# Altium schematic sheet MSXIV_DC-DC_Converter.SchDoc
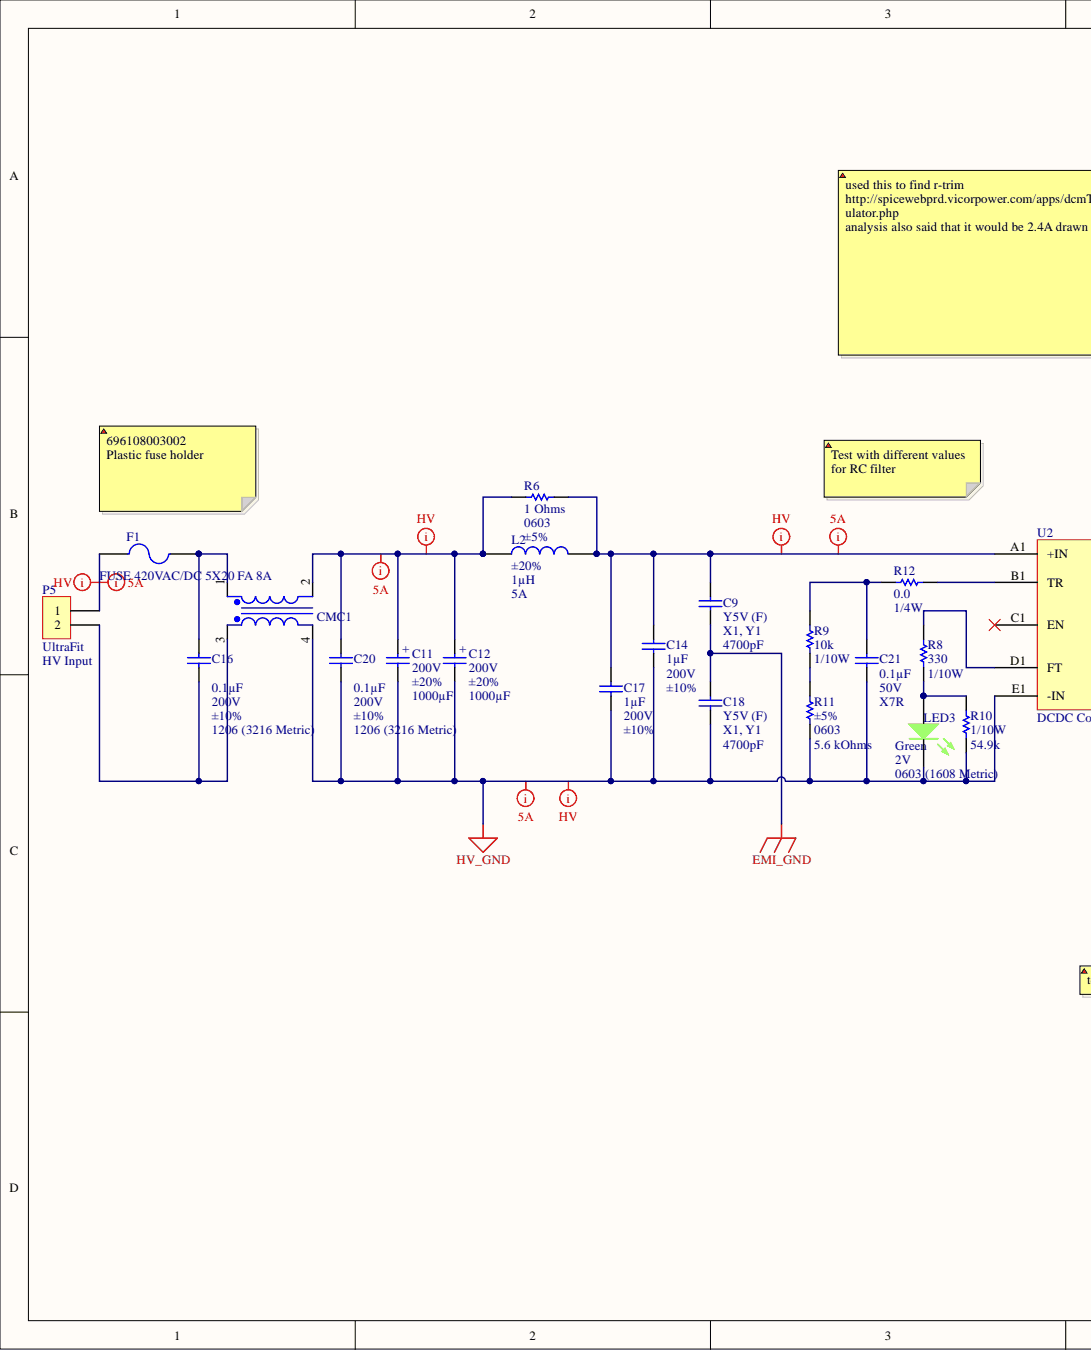
[diagram p0: MSXIV_DC-DC_Converter.SchDoc, left]
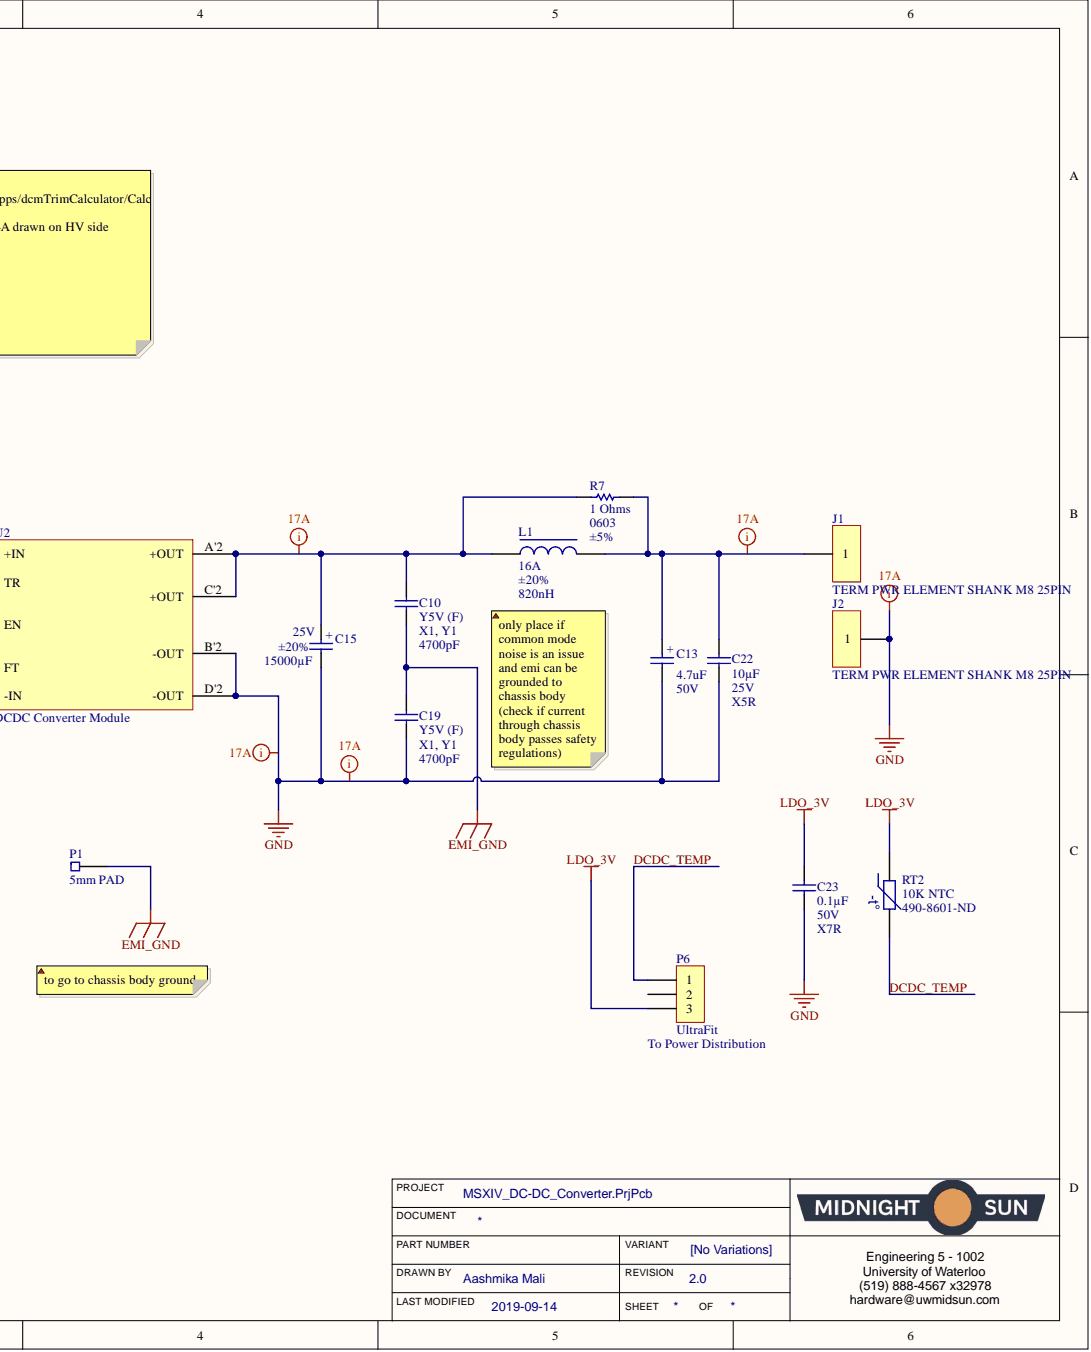
[diagram p1: MSXIV_DC-DC_Converter.SchDoc, right]

## Parts
C9  value 4700pF  Ratings: X1, Y1  Temperature Coefficient: Y5V (F)  [CAP 4700PF 400VAC Y5V RADIAL]  (hidden comment: CAP CER 4700PF 400VAC Y5V RADIAL)
C10  value 4700pF  Ratings: X1, Y1  Temperature Coefficient: Y5V (F)  [CAP 4700PF 400VAC Y5V RADIAL]  (hidden comment: CAP CER 4700PF 400VAC Y5V RADIAL)
C11  Capacitance: 1000µF  Tolerance: ±20%  Voltage - Rated: 200V  [CAP ALUM 1000uF 200V]  (hidden comment: CAP ALUM 1000UF 20% 200V SNAP)
C12  Capacitance: 1000µF  Tolerance: ±20%  Voltage - Rated: 200V  [CAP ALUM 1000uF 200V]  (hidden comment: CAP ALUM 1000UF 20% 200V SNAP)
C13  Capacitance: 4.7uF  Voltage Rating: 50V  [CAP ALUM 6.3MM RADIAL SMD]  (hidden comment: EEE-1HA4R7NP)
C14  Capacitance: 1µF  Voltage - Rated: 200V  [CAP, 2220]  (hidden comment: CAP CER 1UF 200V X7R 2220)
C15  Capacitance: 15000µF  Tolerance: ±20%  Voltage - Rated: 25V  [CAP ALUM 1000uF 200V]  (hidden comment: CAP ALUM 15000UF 20% 25V SNAP)
C16  Capacitance: 0.1µF  Tolerance: ±10%  Voltage - Rated: 200V  [CAP, 1206]  (hidden comment: CAP CER 0.1UF 200V X7R 1206)
C17  Capacitance: 1µF  Tolerance: ±10%  Voltage - Rated: 200V  [CAP, 2220]  (hidden comment: CAP CER 1UF 200V X7R 2220)
C18  value 4700pF  Ratings: X1, Y1  Temperature Coefficient: Y5V (F)  [CAP 4700PF 400VAC Y5V RADIAL]  (hidden comment: CAP CER 4700PF 400VAC Y5V RADIAL)
C19  value 4700pF  Ratings: X1, Y1  Temperature Coefficient: Y5V (F)  [CAP 4700PF 400VAC Y5V RADIAL]  (hidden comment: CAP CER 4700PF 400VAC Y5V RADIAL)
C20  Capacitance: 0.1µF  Tolerance: ±10%  Voltage - Rated: 200V  [CAP, 1206]  (hidden comment: CAP CER 0.1UF 200V X7R 1206)
C21  Capacitance: 0.1µF  Voltage: 50V  [CAP, 0603]  (hidden comment: CAP CER 0.1UF 50V 10% X7R 0603)
C22  Capacitance: 10µF  Temperature Coefficient: X5R  Voltage: 25V  [CAP, 0603]  (hidden comment: CAP CER 10uF 25V 20% X5R 0603)
C23  Capacitance: 0.1µF  Temperature Coefficient: X7R  Voltage: 50V  [CAP, 0603]  (hidden comment: CAP CER 0.1UF 50V 10% X7R 0603)
CMC1  CM Choke  [LCCI 50 Common mode choke]  (value not drawn)
F1  FUSE 420VAC/DC 5X20 FA 8A  [FUSE 420VAC/DC 5X20 FA 8A]
J1  TERM PWR ELEMENT SHANK M8 25PIN  [TERM PWR ELEMENT SHANK M8 25PIN]
J2  TERM PWR ELEMENT SHANK M8 25PIN  [TERM PWR ELEMENT SHANK M8 25PIN]
L1  Current Rating (Amps): 16A  Inductance: 820nH  Tolerance: ±20%  [IND 820NH 16A 6 MOHM SMD]  (hidden comment: IND 820NH 16A 6 MOHM SMD)
L2  Current Rating (Amps): 5A  Inductance: 1µH  Tolerance: ±20%  [IND 1UH 5A 12MOHM SMD]  (hidden comment: IND 1UH 5A 12MOHM SMD)
LED3  Color: Green  Package / Case: 0603 (1608 Metric)  Supplier Device Package: 0603  Voltage - Forward (Vf) (Typ): 2V  [LED_0603]  (hidden comment: LED GREEN CLEAR 2V 0603)
P1  5mm PAD  [5mm PAD]
P5  Series: UltraFit  [CONN, 2POS ULTRA-FIT]  (hidden comment: CONN 2POS ULTRA-FIT 0.138")
P6  Series: UltraFit  [CONN, 3POS ULTRA-FIT]  (hidden comment: CONN 3POS ULTRA-FIT 0.138")
R6  Resistance: 1 Ohms  [RES, 0603]  (hidden comment: RES 1 OHM 5% 1/10W 0603)
R7  Resistance: 1 Ohms  [RES, 0603]  (hidden comment: RES 1 OHM 5% 1/10W 0603)
R8  Power: 1/10W  [RES, 0603]  (hidden comment: RES 330 OHM 1% 1/10W 0603)
R9  Power: 1/10W  Resistance: 10k  [RES, 0603]  (hidden comment: RES 10K OHM 1% 1/10W 0603)
R10  Power (Watts): 1/10W  Resistance (Ohms): 54.9k  [RES, 0603]  (hidden comment: RES 54.9K OHM 1% 1/10W 0603)
R11  Resistance: 5.6 kOhms  Supplier Device Package: 0603  Tolerance: ±5%  [RES, 0603]  (hidden comment: RES 15.6K OHM 0.1% 1/10W 0402)
R12  Resistance: 0.0  [RES, 0603]  (hidden comment: RES 0.0 OHM 1/4W 0603)
RT2  10K NTC  Supplier Part Number 1: 490-8601-ND  [NTC THERMISTOR BEAD]
U2  Type: DCDC Converter Module  [IC, DCDC converter DCM3623TA5N17B4T70]  (hidden comment: DCDC VICOR CONVERTER 15V 240W - DCM3623TA5N17B4T70)

## Nets
EMI_GND: C9.2 C10.2 C18.1 C19.1 P1.1
GND: C13.2 C15.2 C19.2 C22.2 C23.2 J2.1 U2.B'2(-OUT) U2.D'2(-OUT)
HV_GND: C11.2 C12.2 C14.2 C17.2 C18.2 C20.2 C21.2 CMC1.4 LED3.2 R10.2 R11.1 U2.E1(-IN)
LDO_3V: C23.1 P6.3 RT2.1
DCDC_TEMP: P6.1 RT2.2
NetC9_1: C9.1 C14.1 C17.1 L2.2 R6.2 U2.A1(+IN)
NetC10_1: C10.1 C15.1 L1.1 R7.1 U2.A'2(+OUT) U2.C'2(+OUT)
NetC11_1: C11.1 C12.1 C20.1 CMC1.2 L2.1 R6.1
NetC13_1: C13.1 C22.1 J1.1 L1.2 R7.2
NetC16_1: C16.1 CMC1.1 F1.2
NetC16_2: C16.2 CMC1.3 P5.2
NetC21_1: C21.1 R9.1 R12.1
NetF1_1: F1.1 P5.1
NetLED3_1: LED3.1 R8.2 R10.1
NetR8_1: R8.1 U2.D1(FT)
NetR9_2: R9.2 R11.2
NetR12_2: R12.2 U2.B1(TR)

Not connected: P6 2; U2 C1(EN)

## Library data of the parts
C9 C10 C18 C19 = CAP CER 4700PF 400VAC Y5V RADIAL: 4700pF ±20% 400VAC Ceramic Capacitor Y5V (F) Radial, Disc; Manufacturer: KEMET; Manufacturer Part Number: C951U472MVVDBA7317; Applications: Safety; Category: Capacitors; Component Kind: Standard; ComponentLink1Description: https://search.kemet.com/component-edge/download/datasheet/C951U472MVVDBA7317.pdf; ComponentLink1URL: https://search.kemet.com/component-edge/download/datasheet/C951U472MVVDBA7317.pdf; Failure Rate: -; Features: -; Height - Seated (Max): -; Lead Spacing: 0.394" (10.00mm); Lead Style: Formed Leads - Kinked; Mounting Type: Through Hole; Operating Temperature: -40°C ~ 125°C; Package / Case: Radial, Disc; Packaging: Cut Tape (CT); Part Status: Active; Pricing 1: 1=1.12, 10=0.795, 100=0.5234, 500=0.38772 (CAD); RoHS: RoHS Compliant; Size / Dimension: 0.472" Dia (12.00mm); Stock 1: 9713; Supplier 1: Digi-Key; Supplier Part Number 1: 399-9517-1-ND; Thickness (Max): -; Tolerance: ±20%; Voltage - Rated: 400VAC; Library Name: Schematic Diagrams.SchLib
C11 C12 = CAP ALUM 1000UF 20% 200V SNAP: CAP RADIAL ALUM 1000UF 20% 200V SNAP; Manufacturer 1: Cornell Dubilier Electronics (CDE); Manufacturer Part Number 1: SLPX102M200C4P3; ESR (Equivalent Series Resistance): 199mOhm @ 120Hz; Polarization: Polar; Component Kind: Standard; Mounting Type: Through Hole; Package / Case: Radial, Can - Snap-In; Supplier 1: Digi-Key; Supplier Part Number 1: 338-1557-ND; Library Name: Schematic Diagrams.SchLib
C13 = CAP ALUM 4.7UF 20% 50V SMD: 4.7µF 50V Aluminum Electrolytic Capacitors Radial, Can - SMD 2000 Hrs @ 85°C; Manufacturer 1: Panasonic; Description 1: CAPACITOR ALUM ELEC, 4.7UF, 50V, 20%, SMD; Life (Hours): 2000Hour; Ripple Current: 31mA; Tolerance: 20%; Case/Package: Radial; Component Kind: Standard; ComponentLink1Description: https://datasheet.ciiva.com/46374/aba0000ce2-46374373.pdf; ComponentLink1URL: https://datasheet.ciiva.com/46374/aba0000ce2-46374373.pdf; ComponentLink2Description: https://datasheet.ciiva.com/14600/70255710-14600343.pdf; ComponentLink2URL: https://datasheet.ciiva.com/14600/70255710-14600343.pdf; ComponentLink3Description: https://datasheet.ciiva.com/17536/aba0000ce2-17536647.pdf; ComponentLink3URL: https://datasheet.ciiva.com/17536/aba0000ce2-17536647.pdf; ComponentLink4Description: https://datasheet.ciiva.com/24027/aba0000ce2-40801-24027083.pdf; ComponentLink4URL: https://datasheet.ciiva.com/24027/aba0000ce2-40801-24027083.pdf; ComponentLink5Description: https://datasheet.ciiva.com/31414/aba0000ce2-31414684.pdf; ComponentLink5URL: https://datasheet.ciiva.com/31414/aba0000ce2-31414684.pdf; ComponentLink6Description: https://datasheet.ciiva.com/14606/70255710-14606079.pdf; ComponentLink6URL: https://datasheet.ciiva.com/14606/70255710-14606079.pdf; Diameter: 6.3mm; Height: 5.4mm; Height - Seated (Max): 0.213inch; Lead Free: Lead Free; Length: 5.5mm; Manufacturer Part Number 1: EEE-1HA4R7NP; Max Operating Temperature: 85°C; Min Operating Temperature: -40°C; Mount: Surface Mount,PCB; Package Quantity: 1; Packaging: Tape and Reel; Pricing 1: 1=0.43, 10=0.302, 100=0.1986, 500=0.1471 (USD); Radiation Hardening: No; REACH SVHC: No SVHC; Ripple Current (AC): 31mA; Stock 1: 19771; Supplier 1: Digi-Key; Supplier Part Number 1: PCE4304CT-ND; Termination: SMD/SMT; Voltage Rating (DC): 50V; Width: 650um; Library Name: Schematic Diagrams.SchLib
C14 C17 = CAP CER 1UF 200V X7R 2220: 1µF ±10% 200V Ceramic Capacitor X7R 2220 (5750 Metric); Manufacturer: Murata Electronics; Manufacturer Part Number 1: GRM55DR72D105KW01L; Mounting Type 1: Surface Mount, MLCC; Component Kind: Standard; Package / Case: 2220 (5750 Metric); Size / Dimension: 0.224" L x 0.197" W (5.70mm x 5.00mm); Supplier 1: Digi-Key; Supplier Part Number 1: 490-14683-1-ND; Temperature Coefficient: X7R; Library Name: Schematic Diagrams.SchLib
  C14: Tolerance: ±10%
C15 = CAP ALUM 15000UF 20% 25V SNAP: 15000µF 25V Aluminum Electrolytic Capacitors Radial, Can - Snap-In 40mOhm 3000 Hrs @ 105°C; Manufacturer: Cornell Dubilier Electronics (CDE); Manufacturer Part Number: SLP153M025C4P3; Parameter 1: 0.984" Dia (25.00mm); Component Kind: Standard; Size / Dimension: 0.984" Dia (25.00mm); Supplier 1: Digi-Key; Supplier Part Number 1: 338-1470-ND; Library Name: Schematic Diagrams.SchLib
C16 C20 = CAP CER 0.1UF 200V X7R 1206: Manufacturer 1: Manufacturer; Manufacturer Part Number 1: 885342208002; Component Kind: Standard; Description: CAP CER 0.1UF 200V X7R 1206; Package / Case: 1206 (3216 Metric); Supplier 1: Digi-Key; Supplier Part Number 1: 732-12081-1-ND; Temperature Coefficient: X7R; Library Name: Schematic Diagrams.SchLib
C21 C23 = CAP CER 0.1UF 50V 10% X7R 0603: 0.10µF ±10% 50V Ceramic Capacitor X7R 0603 (1608 Metric); Manufacturer 1: AVX Corporation; Manufacturer Part Number 1: 06035C104KAT2A; Component Kind: Standard; ComponentLink1Description: http://datasheets.avx.com/X7RDielectric.pdf; ComponentLink1URL: http://datasheets.avx.com/X7RDielectric.pdf; Height - Seated (Max): -; Lead Spacing: -; Lead Style: -; Mounting Type: Surface Mount, MLCC; Operating Temperature: -55°C ~ 125°C; Package / Case: 0603 (1608 Metric); Packaging: Cut Tape (CT); Part Status: Active; Ratings: -; Size / Dimension: 0.063" L x 0.032" W (1.60mm x 0.81mm); Supplier 1: Digi-Key; Supplier Part Number 1: 478-5052-1-ND; Thickness (Max): 0.035" (0.90mm); Tolerance: ±10%; Library Name: Schematic Diagrams.SchLib
  C21: Temperature Coefficient: X7R
C22 = CAP CER 10uF 25V 20% X5R 0603: 10µF ±20% 25V Ceramic Capacitor X5R 0603 (1608 Metric); Manufacturer 1: Murata Electronics North America; Manufacturer Part Number 1: GRM188R61E106MA73D; Component Kind: Standard; ComponentLink1Description: http://www.murata.com/~/media/webrenewal/support/library/catalog/products/capacitor/mlcc/c02e.ashx; ComponentLink1URL: http://www.murata.com/~/media/webrenewal/support/library/catalog/products/capacitor/mlcc/c02e.ashx; Height - Seated (Max): -; Lead Spacing: -; Lead Style: -; Mounting Type: Surface Mount, MLCC; Operating Temperature: -55°C ~ 85°C; Package / Case: 0603 (1608 Metric); Packaging: Cut Tape (CT); Part Status: Active; Ratings: -; Size / Dimension: 0.063" L x 0.031" W (1.60mm x 0.80mm); Supplier 1: Digi-Key; Supplier Part Number 1: 490-7202-1-ND; Thickness (Max): 0.031" (0.80mm); Tolerance: ±20%; Library Name: Schematic Diagrams.SchLib
CMC1 = COMMON MODE CHOKE 5.6A 2LN SMD: 2 Line Common Mode Choke Surface Mount  5.6A DCR 21mOhm; Manufacturer 1: Pulse Electronics Power; Manufacturer Part Number 1: P0353NLT; PartNumber: P0353NL; Filter Type: Power Line; Number of Lines: 2; Component Kind: Standard; Current Rating (Max): 5.6A; DC Resistance (DCR) (Max): 21mOhm; Package: TBD; Supplier 1: Digi-Key; Supplier Part Number 1: 553-1764-1-ND; Library Name: Schematic Diagrams.SchLib
F1 = FUSE 420VAC/DC 5X20 FA 8A: 8A 420V AC 420V DC Fuse Cartridge, Ceramic Holder Cartridge, Non-Standard; Manufacturer: Littelfuse Inc.; Manufacturer Part Number: 0487008.MXP; Component Kind: Standard; Fuse Type: Cartridge, Ceramic; Supplier 1: Digi-Key; Supplier Part Number 1: F6659-ND; Voltage Rating - DC: 420V; Library Name: Schematic Diagrams.SchLib
J1 J2 = TERM PWR ELEMENT SHANK M8 25PIN: 25 Pin Shank Terminal M8 Through Hole; Manufacturer 1: Wurth Electronics Inc.; Manufacturer Part Number 1: 7460719; Current Rating (Amps): 250A; Component Kind: Standard; Number of Pins: 25; Screw Size: M8; Supplier 1: Digi-Key; Supplier Part Number 1: 732-3219-ND; Terminal Type: Shank Terminal; Termination: Press-Fit; Library Name: Schematic Diagrams.SchLib
L1 = IND 820NH 16A 6 MOHM SMD: 820nH Shielded Molded Inductor 16A 6mOhm Max Nonstandard; Manufacturer: ECS Inc.; Manufacturer Part Number: ECS-MPIL0630-R82MC; Component Kind: Standard; DC Resistance (DCR): 6mOhm Max; Height - Seated (Max): 0.118" (3.00mm); Mounting Type: Surface Mount; Package / Case: Nonstandard; Shielding: Shielded; Size / Dimension: 0.291" L x 0.260" W (7.40mm x 6.60mm); Supplier 1: Digi-Key; Supplier Part Number 1: XC2399CT-ND; Library Name: Schematic Diagrams.SchLib
L2 = IND 1uH 5A 12MOHM SMD: 1µH Semi-Shielded Wirewound Inductor 5A 12mOhm Nonstandard; Manufacturer 1: Bourns Inc.; Manufacturer Part Number 1: SRN5040TA-1R0M; Current - Saturation: 7.5A; Component Kind: Standard; DC Resistance (DCR): 12mOhm; Mounting Type: Surface Mount; Package / Case: Nonstandard; Shielding: Semi-Shielded; Supplier 1: Digi-Key; Supplier Part Number 1: SRN5040TA-1R0MCT-ND; Library Name: Schematic Diagrams.SchLib
LED3 = LED GREEN CLEAR 2V 0603: Green 572nm LED Indication - Discrete 2V 0603 (1608 Metric); Manufacturer: Wurth Electronics Inc.; Manufacturer Part Number: 150060VS75000; Current - Test: 20mA; Lens Color: Colorless; Lens Style/Size: Rectangle with Flat Top, 1.10mm x 0.70mm; Millicandela Rating: 40mcd; Viewing Angle: 140°; Wavelength - Dominant: 570nm; Category: Optoelectronics; Component Kind: Standard; ComponentLink1Description: http://katalog.we-online.de/led/datasheet/150060VS75000.pdf; ComponentLink1URL: http://katalog.we-online.de/led/datasheet/150060VS75000.pdf; Features: -; Height (Max): 0.80mm; Lens Transparency: Clear; Mounting Type: Surface Mount; Packaging: Cut Tape (CT); Part Status: Active; Pricing 1: 1=0.21, 100=0.1617, 500=0.13964 (CAD); RoHS: RoHS Compliant; Size / Dimension: 1.60mm L x 0.80mm W; Stock 1: 9408; Supplier 1: Digi-Key; Supplier Part Number 1: 732-4980-1-ND; Wavelength - Peak: 572nm; Library Name: Schematic Diagrams.SchLib
P1 = 5mm PAD: 5x5mm pad to solder 16 gauge wire; Component Kind: Standard; Library Name: Schematic Diagrams.SchLib
P5 = CONN 2POS ULTRA-FIT 0.138": 2 Positions Header, Shrouded Connector 0.138" (3.50mm) Through Hole Gold; Manufacturer 1: Molex, LLC; Manufacturer Part Number 1: 1722861302; Contact Length - Post: 0.126" (3.20mm); Mated Stacking Heights: 26.99mm; Material Flammability Rating: UL94 V-0; Number of Positions: 2; Number of Positions Loaded: All; Shrouding: Shrouded - 4 Wall; Style: Board to Board or Cable; Voltage: 400V; Component Kind: Standard; ComponentLink1Description: http://www.molex.com/pdm_docs/sd/1722861302_sd.pdf; ComponentLink1URL: http://www.molex.com/pdm_docs/sd/1722861302_sd.pdf; Connector Type: Header; Contact Finish - Mating: Gold; Contact Finish - Post: Tin; Contact Finish Thickness - Mating: 30µin (0.76µm); Contact Finish Thickness - Post: 60µin (1.52µm); Contact Length - Mating: -; Contact Material: Copper Alloy; Contact Shape: Square; Contact Type: Male Pin; Current Rating: -; Fastening Type: Latch Holder; Ingress Protection: -; Insulation Color: Black; Insulation Height: 0.465" (11.80mm); Insulation Material: Liquid Crystal Polymer (LCP); Mounting Type: Through Hole; Number of Rows: 1; Operating Temperature: -40°C ~ 120°C; Overall Contact Length: -; Packaging: Tray; Part Status: Active; Pitch - Mating: 0.138" (3.50mm); Row Spacing - Mating: -; Supplier 1: Digi-Key; Supplier Part Number 1: WM11673-ND; Termination: Kinked Pin, Solder; Library Name: Schematic Diagrams.SchLib
P6 = CONN 3POS ULTRA-FIT 0.138": 3 Positions Header Connector 0.138" (3.50mm) Through Hole Tin; Manufacturer 1: Molex, LLC; Manufacturer Part Number 1: 1722871103; Contact Length - Post: 0.126" (3.20mm); Mated Stacking Heights: 26.99mm; Material Flammability Rating: UL94 V-0; Number of Positions: 3; Number of Positions Loaded: All; Shrouding: Shrouded - 4 Wall; Style: Board to Cable/Wire; Voltage: 400V; Component Kind: Standard; ComponentLink1Description: http://www.molex.com/pdm_docs/sd/1722871103_sd.pdf; ComponentLink1URL: http://www.molex.com/pdm_docs/sd/1722871103_sd.pdf; Connector Type: Header; Contact Finish - Mating: Tin; Contact Finish - Post: Tin; Contact Finish Thickness - Mating: 60µin (1.52µm); Contact Finish Thickness - Post: 60µin (1.52µm); Contact Length - Mating: -; Contact Material: Copper Alloy; Contact Shape: Square; Contact Type: Male Pin; Current Rating: -; Fastening Type: Latch Holder; Ingress Protection: -; Insulation Color: Black; Insulation Height: 0.465" (11.80mm); Insulation Material: Liquid Crystal Polymer (LCP); Mounting Type: Through Hole; Number of Rows: 1; Operating Temperature: -40°C ~ 105°C; Overall Contact Length: -; Packaging: Tray; Part Status: Active; Pitch - Mating: 0.138" (3.50mm); Row Spacing - Mating: -; Supplier 1: Digi-Key; Supplier Part Number 1: WM11702-ND; Termination: Solder; Library Name: Schematic Diagrams.SchLib
R6 R7 = RES 1 OHM 5% 1/10W 0603: RES SMD 1 OHM 5% 1/10W 0603; Manufacturer 1: Rohm Semiconductor; Manufacturer Part Number 1: KTR03EZPJ1R0; Detailed Description : 1 Ohms ±5% 0.1W, 1/10W Chip Resistor 0603 (1608 Metric) Automotive AEC-Q200, High Voltage Thick Film; Power (Watts): 0.1W, 1/10W; Component Kind: Standard; Digi-Key Part Number: RHM1.0AZCT-ND; Package / Case: 0603 (1608 Metric); Supplier 1: Digi-Key; Supplier Device Package: 0603; Supplier Part Number 1: RHM1.0AZCT-ND; Tolerance: ±5%; Library Name: Schematic Diagrams.SchLib
R8 = RES 330 OHM 1% 1/10W 0603: 330 Ohms ±1% 0.1W, 1/10W Chip Resistor 0603 (1608 Metric) Automotive AEC-Q200, Moisture Resistant Thick Film; Manufacturer: TE Connectivity; Manufacturer Part Number: 1-2176339-9; Resistance: 330; Component Kind: Standard; Stock 1: 0; Supplier 1: Digi-Key; Supplier Part Number 1: A129682TR-ND; Library Name: Schematic Diagrams.SchLib
R9 = RES 10K OHM 1% 1/10W 0603: RES SMD 10K OHM 1% 1/10W 0603; Manufacturer 1: Yageo; Manufacturer Part Number 1: RC0603FR-0710KL; Temperature Coefficient: ±100ppm/°C; Component Kind: Standard; ComponentLink1Description: http://www.yageo.com/documents/recent/PYu-RC_Group_51_RoHS_L_7.pdf; ComponentLink1URL: http://www.yageo.com/documents/recent/PYu-RC_Group_51_RoHS_L_7.pdf; Composition: Thick Film; Failure Rate: -; Height - Seated (Max): 0.022" (0.55mm); Operating Temperature: -55°C ~ 155°C; Package / Case: 0603 (1608 Metric); Packaging: Cut Tape (CT); Part Status: Active; Size / Dimension: 0.063" L x 0.031" W (1.60mm x 0.80mm); Supplier 1: Digi-Key; Supplier Device Package: 0603; Supplier Part Number 1: 311-10.0KHRCT-ND; Tolerance: ±1%; Library Name: Schematic Diagrams.SchLib
R10 = RES 54.9K OHM 1% 1/10W 0603: 54.9k Ohm ±1% 0.1W, 1/10W Chip Resistor 0603 (1608 Metric) Automotive AEC-Q200 Thick Film; Manufacturer: Panasonic Electronic Components; Manufacturer Part Number: ERJ-3EKF5492V; Temperature Coefficient: ±100ppm/°C; Category: Resistors; Component Kind: Standard; ComponentLink1Description: https://industrial.panasonic.com/cdbs/www-data/pdf/RDA0000/AOA0000C298.pdf; ComponentLink1URL: https://industrial.panasonic.com/cdbs/www-data/pdf/RDA0000/AOA0000C298.pdf; Composition: Thick Film; Failure Rate: -; Features: Automotive AEC-Q200; Height - Seated (Max): 0.022" (0.55mm); Operating Temperature: -55°C ~ 155°C; Package / Case: 0603 (1608 Metric); Packaging: Cut Tape (CT); Part Status: Active; Pricing 1: 1=0.16, 10=0.031, 100=0.0127, 1000=0.00567, 2500=0.00492 (CAD); RoHS: RoHS Compliant; Size / Dimension: 0.063" L x 0.031" W (1.60mm x 0.80mm); Stock 1: 74038; Supplier 1: Digi-Key; Supplier Device Package: 0603; Supplier Part Number 1: P54.9KHCT-ND; Tolerance: ±1%; Library Name: Schematic Diagrams.SchLib
R11 = RES 5.6K OHM 5% 1/10W 0603: 5.6 kOhms ±5% 0.1W, 1/10W Chip Resistor 0603 (1608 Metric) Moisture Resistant Thick Film; Manufacturer 1: Yageo; Manufacturer Part Number 1: RC0603JR-075K6L; Component Kind: Standard; Supplier 1: Digi-Key; Supplier Part Number 1: 311-5.6KGRCT-ND; Library Name: Schematic Diagrams.SchLib
R12 = RES 0.0 OHM 1/4W 0603: RES SMD 0.0 OHM JUMPER 1/4W 0603; Manufacturer 1: Vishay Dale; Manufacturer Part Number 1: CRCW06030000Z0EAHP; Component Kind: Standard; ComponentLink1Description: http://www.vishay.com/docs/20043/crcwhpe3.pdf; ComponentLink1URL: http://www.vishay.com/docs/20043/crcwhpe3.pdf; Composition: Thick Film; Failure Rate: -; Height - Seated (Max): 0.022" (0.55mm); Operating Temperature: -55°C ~ 155°C; Package / Case: 0603 (1608 Metric); Packaging: Cut Tape (CT); Part Status: Active; Power (Watts): 1/4W; Size / Dimension: 0.063" L x 0.033" W (1.60mm x 0.85mm); Supplier 1: Digi-Key; Supplier Device Package: 0603; Supplier Part Number 1: 541-0.0SBCT-ND; Library Name: Schematic Diagrams.SchLib
RT2 = NTC THERMISTOR 10K 1% BEAD: NTC Thermistor 10k Bead; Manufacturer: Murata Electronics North America; Manufacturer Part Number: NXRT15XH103FA1B030; B25/50: 3380K; B25/85: 3434K; B25/100: 3455K; B Value Tolerance: ±1%; B0/50: -; B25/75: -; Category: Sensors, Transducers; Component Kind: Standard; ComponentLink1Description: https://www.murata.com/~/media/webrenewal/support/library/catalog/products/thermistor/ntc/r44e.ashx; ComponentLink1URL: https://www.murata.com/~/media/webrenewal/support/library/catalog/products/thermistor/ntc/r44e.ashx; Length - Lead Wire: -; Mounting Type: Through Hole; Operating Temperature: -40°C ~ 125°C; Package / Case: Bead; Packaging: Bulk; Part Status: Active; Pricing 1: 1=0.96, 5=0.91, 10=0.792, 25=0.696, 50=0.5784, 100=0.4925, 500=0.42834, 1000=0.3748, 5000=0.33197 (CAD); Resistance in Ohms @ 25°C: 10k; Resistance Tolerance: ±1%; RoHS: RoHS Compliant; Stock 1: 25017; Supplier 1: Digi-Key; Library Name: Schematic Diagrams.SchLib
U2 = DCDC VICOR CONVERTER 15V 240W - DCM3623TA5N17B4T70: DC DC CONVERTER 15V 240W; Manufacturer 1: Vicor Corporation; Current - Output (Max): 16A; Efficiency: 92.1%; Number of Outputs: 1; Voltage - Input (Max): 43V; Voltage - Input (Min): 154V; Component Kind: Standard; ComponentLink1Description: http://www.vicorpower.com/documents/datasheets/DCM3623xA5N17B4y7z_ds.pdf; ComponentLink1URL: http://www.vicorpower.com/documents/datasheets/DCM3623xA5N17B4y7z_ds.pdf; Manufacturer Part Number 1: DCM3623TA5N17B4T70; Mounting Type: Surface Mount; Operating Temperature: -40°C ~ 105°C; Package / Case: 9-DIP Module; Packaging: Cut Tape (CT); Part Status: Active; Power: 240W; Size / Dimension: 1.52" L x 0.90" W x 0.28" H (38.72mm x 22.8mm x 7.2mm); Supplier 1: Digi-Key; Supplier Part Number 1: 1470-2950-1-ND; Voltage - Output 1: 15V; Voltage - Output 2: -; Voltage - Output 3: -; Library Name: Schematic Diagrams.SchLib
